# Altium schematic sheet led chaser.SchDoc("led chaser")
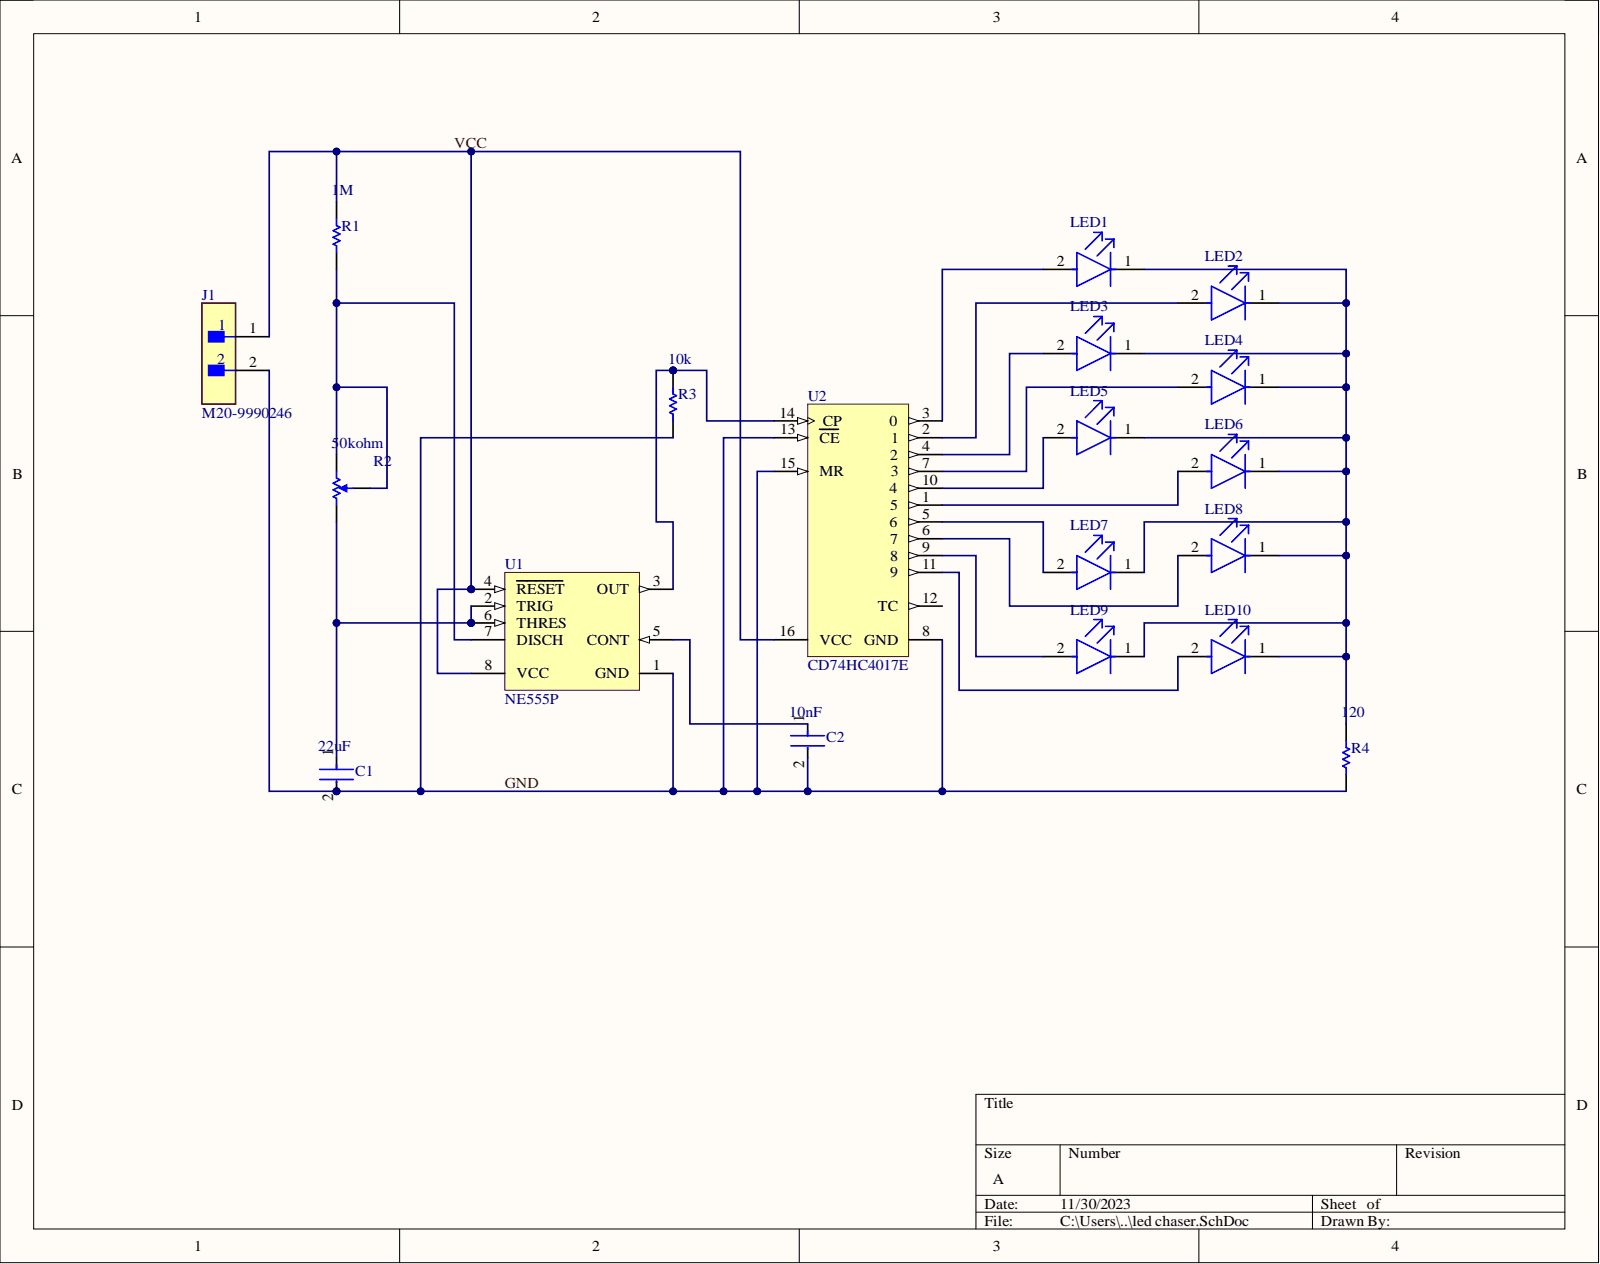
[diagram p0: led chaser.SchDoc]

## Parts
C1  Capacitance: 22uF  [FP-RCEWM1-MFG]  (hidden comment: RCER71E226MWM1H03A)
C2  Capacitance: 10nF  [FP-RCEWM1-MFG]  (hidden comment: RCER71E226MWM1H03A)
J1  M20-9990246  [FP-M20-9990246-MFG]
LED1  SML-P11UTT86  [FP-SML-P11UTT86-MFG]  (value not drawn)
LED2  SML-P11UTT86  [FP-SML-P11UTT86-MFG]  (value not drawn)
LED3  SML-P11UTT86  [FP-SML-P11UTT86-MFG]  (value not drawn)
LED4  SML-P11UTT86  [FP-SML-P11UTT86-MFG]  (value not drawn)
LED5  SML-P11UTT86  [FP-SML-P11UTT86-MFG]  (value not drawn)
LED6  SML-P11UTT86  [FP-SML-P11UTT86-MFG]  (value not drawn)
LED7  SML-P11UTT86  [FP-SML-P11UTT86-MFG]  (value not drawn)
LED8  SML-P11UTT86  [FP-SML-P11UTT86-MFG]  (value not drawn)
LED9  SML-P11UTT86  [FP-SML-P11UTT86-MFG]  (value not drawn)
LED10  SML-P11UTT86  [FP-SML-P11UTT86-MFG]  (value not drawn)
R1  Resistance: 1M  [RESA60-630X240]  (hidden comment: MFR-25FBF52-1K)
R2  Track Resistance: 50kohm  [BOUR-3313J-1_V]  (hidden comment: 3313J-1-503E)
R3  Resistance (Ohms): 10k  [OMTE-RES7X2.5-2]  (hidden comment: OD103JE)
R4  MFR-25FBF52-1K  [RESA60-630X240]  (value not drawn)
U1  NE555P  [P0008A]
U2  CD74HC4017E  [N0016A]

## Nets
GND: C1.2 C2.2 J1.2 R3.1 R4.1 U1.1(GND) U2.8(GND) U2.13(CE) U2.15(MR)
VCC: J1.1 R1.2 U1.4(RESET) U1.8(VCC) U2.16(VCC)
NetC1_1: C1.1 R2.1 U1.2(TRIG) U1.6(THRES)
NetC2_1: C2.1 U1.5(CONT)
NetLED1_1: LED1.1 LED2.1 LED3.1 LED4.1 LED5.1 LED6.1 LED7.1 LED8.1 LED9.1 LED10.1 R4.2
NetLED1_2: LED1.2 U2.3
NetLED2_2: LED2.2 U2.2
NetLED3_2: LED3.2 U2.4
NetLED4_2: LED4.2 U2.7
NetLED5_2: LED5.2 U2.10
NetLED6_2: LED6.2 U2.1
NetLED7_2: LED7.2 U2.5
NetLED8_2: LED8.2 U2.6
NetLED9_2: LED9.2 U2.9
NetLED10_2: LED10.2 U2.11
NetR1_1: R1.1 R2.2 R2.3 U1.7(DISCH)
NetR3_2: R3.2 U1.3(OUT) U2.14(CP)

Not connected: U2 12(TC)

## Library data of the parts
C1 C2 = CMP-06035-003622-1: CAP CER 22UF 25V X7R RADIAL; Lead Diameter: 0.5mm; Lead/Base Style: Formed Leads; Tolerance: 20%; Case/Package: Radial; Component Kind: Standard; Dielectric: X7R; Height: 7.5mm; Height - Seated (Max): 0.295inch; Lead Pitch: 0.197inch; Length: 0.217inch; Library Name: Altium Content Vault; Max Operating Temperature: 125°C; Min Operating Temperature: -55°C; Mount: Through Hole; Package Quantity: 1500; Packaging: Tape and Reel; Ratings: AEC-Q200; Resistor Type: Ceramic; Termination: Radial; Voltage Rating: 25V; Voltage Rating (DC): 25V; Width: 0.157inch
J1 = CMP-15831-000009-1: CONN HEADER VERT 2POS 2.54MM; Manufacturer: Harwin; Conn Gender: Male; Conn Orientation: Vertical; Current Rating: 3A; Number Of Contacts: 2; Automotive: No; Category: Conn; Component Kind: Standard; ComponentLink2Description: Datasheet; ComponentLink2URL: https://cdn.harwin.com/pdfs/M20-999.pdf; Contact Material: Tin,Nickel; Device Class L1: Connectors; Device Class L2: Headers and Wire Housings; Device Class L3: unset; Digikey Description: CONN HEADER VERT 2POS 2.54MM; Height: 8.99mm; Lead Free: Yes; Library Name: Altium Content Vault; Manufacturer Part Number: M20-9990246; Mouser Description: 2.54mm (0.1") Pitch SIL Vertical PC Tail Pin Header, 6.1mm mating pin height, tin, 2 contacts; Number Of Rows: 1; Octopart: https://octopart.com/m20-9990246-harwin-2590635; Package: M20-9990246; Pitch: 2.54mm; RoHS: TRUE; Temperature: -40°C to +105°C; Temperature Range High: +105°C; Temperature Range Low: -40°C
LED1-LED10 = CMP-08952-000028-1: LED RED CLEAR 1006 SMD; Dominant Wavelength: 621nm; Forward Current: 20mA; Forward Voltage: 1.8V; Lens Color: Colorless; Lens Style: Square; Luminous Intensity: 5.5mcd; Number of LEDs: 1; Test Current: 1mA; Viewing Angle: 150°; Case Code (Imperial): 0402; Case Code (Metric): 1005; Case/Package: 0402; Color: Red; Component Kind: Standard; Height: 0.25mm; Illumination Color: Red; Lead Free: Lead Free; Length: 1mm; Lens Transparency: Clear; Library Name: Altium Content Vault; Max Operating Temperature: 85°C; Min Operating Temperature: -40°C; Mount: Surface Mount; Number of Pins: 2; Package Quantity: 1; Packaging: Tape and Reel; Radiation Hardening: No; REACH SVHC: No SVHC; RoHS Compliant: Yes; Series: PicoLED™; Wavelength: 621nm; Width: 0.6mm
R1 = CMP-1659-00075-1: Axial Resistor, 1 KOhm, +/- 1%, 250 mW, -55 to 155 degC, 2-Pin THD, RoHS, Bulk; Power Rating: 250 mW; Voltage Rating: 250 V; Case\Package: Axial; Component Kind: Standard; ComponentLink1Description: Manufacturer URL; ComponentLink1URL: http://www.yageo.com/; ComponentLink2Description: Datasheet; ComponentLink2URL: http://www.yageo.com/documents/recent/Yageo%20LR_MFR_2013.pdf; DatasheetVersion: Apr-13; Length: 0.248 inch; Library Name: Altium Content Vault; Max Operating Temperature: 155 degC; Min Operating Temperature: -55 degC; Package Quantity: 200; PackageDescription: 2-Pin Axial Lead, Dia 2.4 mm, Body Length 6.3 mm; PackageReference: MFR-25; Packaging: Bulk; RoHSCompliant: true; Tolerance: 1%
R2 = CMP-2000-05588-1: 3 mm Trimpot(R) Trimming Potentiometer, 50 KOhm, +/- 20%, 0.125 W, -55 to 125 degC, 3-Pin SMD, RoHS, Tape and Reel; Manufacturer: Bourns; No. of Turns: 1; Power Rating: 125mW; SVHC: NoSVHC(19-Dec-2012); Temperature Coefficient: ±150ppm/°C; Component Kind: Standard; Datasheet URL: http://www.bourns.com/docs/Product-Datasheets/3313.pdf; Datasheet Version: 05/2016; Library Name: Altium Content Vault; Manufacturer URL: http://www.bourns.com/; Mounting Technology: SurfaceMount; Package Description: 3-Pin Surface Mount Device, Body 3.5 x 3.2 mm, Pitch 1.15 mm; Package Reference: 3313J-1; Potentiometer Mounting: SMD; Resistance Tolerance: ±20%
R3 = CMP-2000-06865-1: Little Demon(R) Carbon Composition Molded OD Series, 250 V, 10 KOhm, +/-5%, -70 to 130 degC, 2-Pin THD, RoHS, Bulk; Manufacturer: Ohmite; Catalog Drawings: OD,OF,OASeries; EDA / CAD Models: DownloadfromAcceleratedDesigns; Online Catalog: OD,OF,OASeries,LittleDemon®; Power (Watts): 0.25W,1/4W; Power Rating: 0.25W; Tolerance: ±5%; Category: Resistors; Component Kind: Standard; Composition: CarbonComposition; Datasheet URL: http://www.ohmite.com/cat/res_od_of_oa.pdf; Datasheet Version: 06/2016; Family: ThroughHoleResistors; Features: PulseWithstanding; Height: -; Library Name: Altium Content Vault; Manufacturer URL: http://www.ohmite.com/; Mounting Technology: ThroughHole; Number of Terminations: 2; Operating Temperature: -; Package / Case: Axial; Package Description: 2-Pin THD Resistor, Body 7 x 2.5 mm, Lead Dia. 0.6 mm; Package Reference: RES7X2.5; Packaging: Bulk; PartNumber: OD103JE; RoHS Information: LittleDemon"E"SeriesMaterialDeclaration; Series: LittleDemon®OD; Size / Dimension: 0.098"Diax0.276"L(2.50mmx7.00mm); Standard Package: 100; Supplier Device Package: Axial; Temperature Coefficient: -
R4 = CMP-1659-00075-1: Axial Resistor, 1 KOhm, +/- 1%, 250 mW, -55 to 155 degC, 2-Pin THD, RoHS, Bulk; Power Rating: 250 mW; Resistance: 120; Voltage Rating: 250 V; Case\Package: Axial; Component Kind: Standard; ComponentLink1Description: Manufacturer URL; ComponentLink1URL: http://www.yageo.com/; ComponentLink2Description: Datasheet; ComponentLink2URL: http://www.yageo.com/documents/recent/Yageo%20LR_MFR_2013.pdf; DatasheetVersion: Apr-13; Length: 0.248 inch; Library Name: Altium Content Vault; Max Operating Temperature: 155 degC; Min Operating Temperature: -55 degC; Package Quantity: 200; PackageDescription: 2-Pin Axial Lead, Dia 2.4 mm, Body Length 6.3 mm; PackageReference: MFR-25; Packaging: Bulk; RoHSCompliant: true; Tolerance: 1%
U1 = CMP-2000-04954-1: Precision Timer, 4.5 to 16 V, 0 to 70 degC, 8-Pin DIP, Pb-Free, Tube; Manufacturer: TexasInstruments; Maximum High Level Output Current: -200mA; Maximum Low Level Output Current: 200mA; Number of Internal Timers: 1; Operating Supply Voltage: 5; Rad Hard: No; Category: Timer; Component Kind: Standard; Datasheet URL: http://www.ti.com/general/docs/lit/getliterature.tsp?genericPartNumber=NE555&fileType=pdf; Datasheet Version: Rev. I, 09/2014; Library Name: Altium Content Vault; Manufacturer URL: http://www.ti.com/; Package: 8PDIP; Package Description: 8-Pin Double In-line Package, Body 9.59 x 6.35 mm, Pitch 2.54 mm; Package Reference: P8; Package URL: http://www.ti.com/lit/ml/mpdi001b/mpdi001b.pdf; Packaging: Rail/Tube; Type: Standard
U2 = CMP-1623-00548-2: High Speed CMOS Logic Decade Counter/Divider with 10 Decoded Outputs, N0016A, TUBE; Manufacturer: Texas Instruments; Bits(#): 10; F @ Nom Voltage(Max)(Mhz): 28; ICC @ Nom Voltage(Max)(mA): 0.08; Input Type: LVTTL/CMOS; Inputs: 1; Output Drive (IOL/IOH)(Max)(mA): 5.2/-5.2; Rating: Catalog; Schmitt Trigger: No; Technology Family: HC; tpd @ Nom Voltage(Max)(ns): 54; VCC(Max)(V): 6; VCC(Min)(V): 2; Voltage(Nom)(V): 3.3,5; Code_JEDEC: MS-001; Component Kind: Standard; DatasheetVersion: SCHS200D; Function: Counter; Generic Part Number: CD74HC4017; Library Name: Altium Content Vault; Operating Temperature Range(C): -55 to 125; Output Type: CMOS; PackageReference: N0016A; Type: Decade
